# Altium schematic sheet Sheet2.SchDoc(Sheet2)
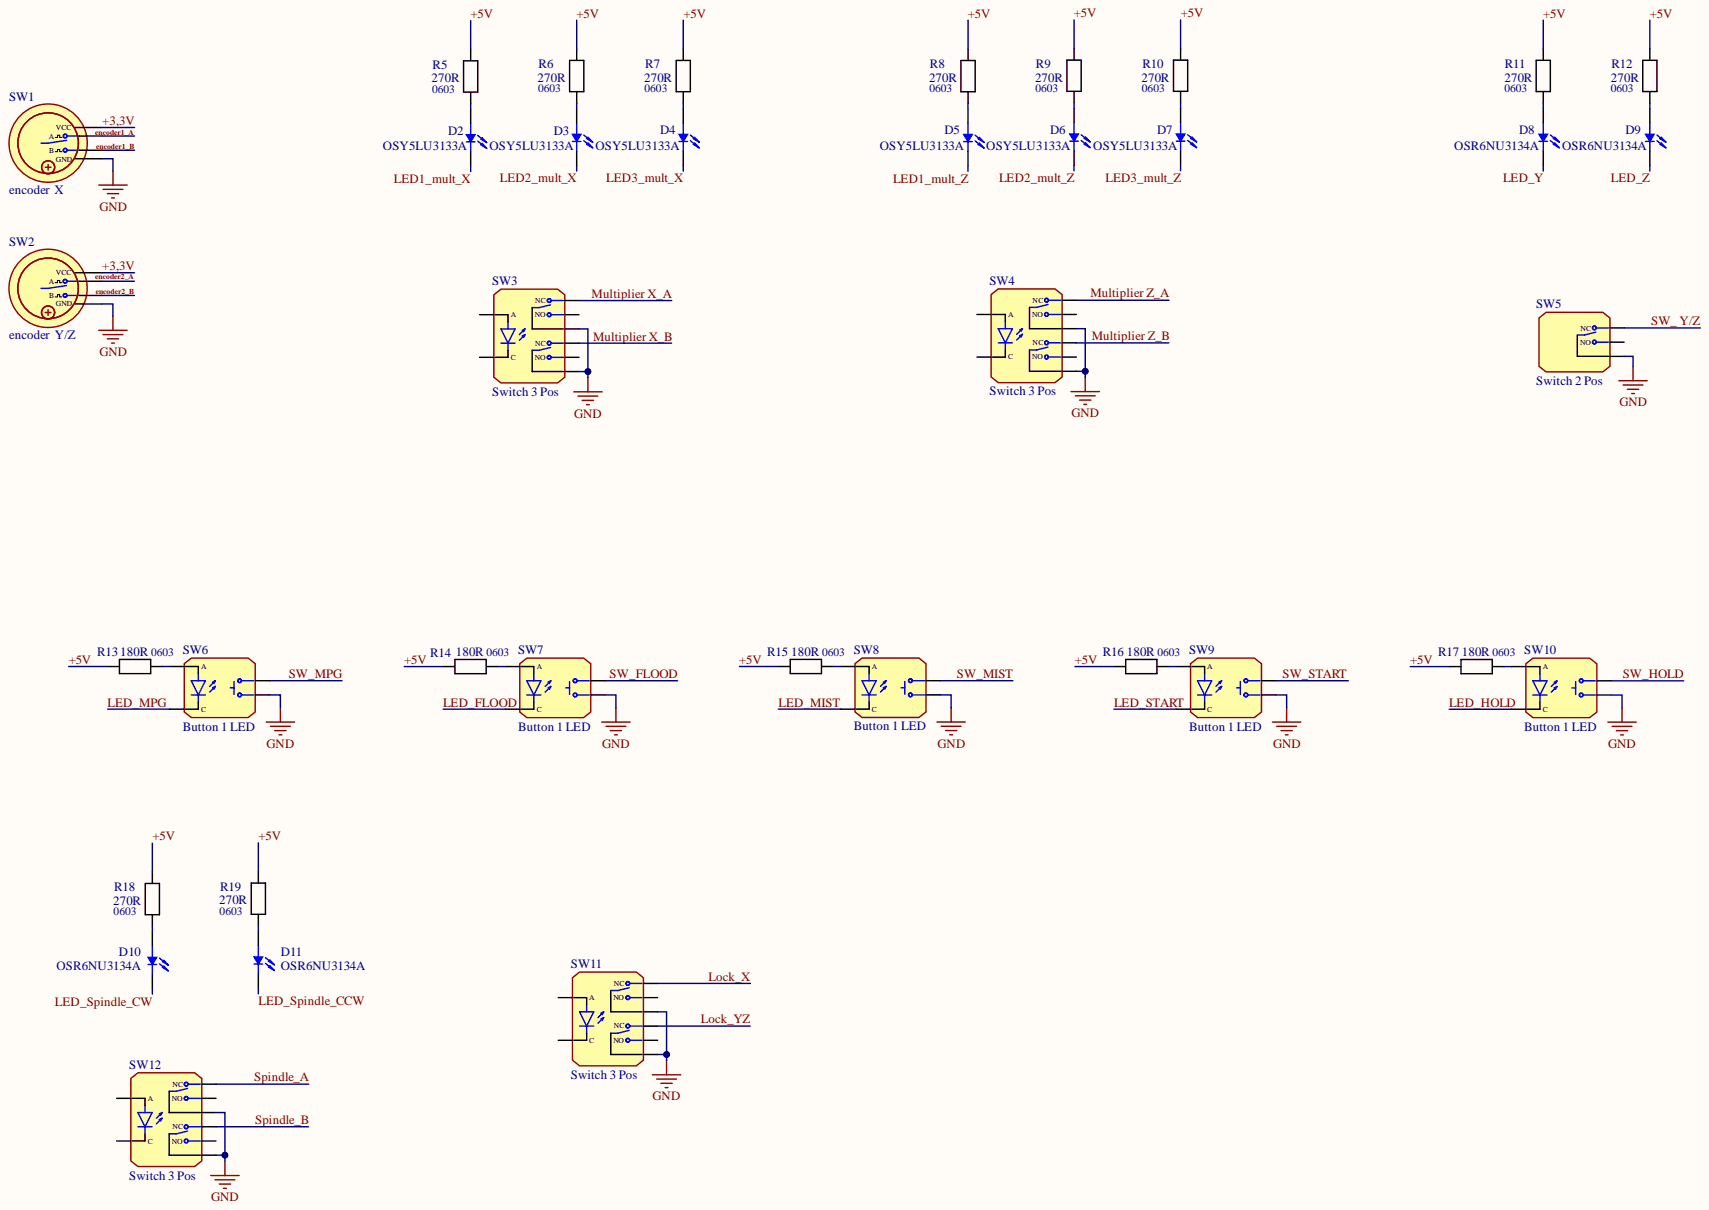
[diagram p0: Sheet2.SchDoc]

## Parts
D2  OSY5LU3133A  [LED-3mm Y]
D3  OSY5LU3133A  [LED-3mm Y]
D4  OSY5LU3133A  [LED-3mm Y]
D5  OSY5LU3133A  [LED-3mm Y]
D6  OSY5LU3133A  [LED-3mm Y]
D7  OSY5LU3133A  [LED-3mm Y]
D8  OSR6NU3134A  [LED-3mm R]
D9  OSR6NU3134A  [LED-3mm R]
D10  OSR6NU3134A  [LED-3mm R]
D11  OSR6NU3134A  [LED-3mm R]
R5  270R  Case: 0603  [SMD 0603]
R6  270R  Case: 0603  [SMD 0603]
R7  270R  Case: 0603  [SMD 0603]
R8  270R  Case: 0603  [SMD 0603]
R9  270R  Case: 0603  [SMD 0603]
R10  270R  Case: 0603  [SMD 0603]
R11  270R  Case: 0603  [SMD 0603]
R12  270R  Case: 0603  [SMD 0603]
R13  180R  Case: 0603  [SMD 0603]
R14  180R  Case: 0603  [SMD 0603]
R15  180R  Case: 0603  [SMD 0603]
R16  180R  Case: 0603  [SMD 0603]
R17  180R  Case: 0603  [SMD 0603]
R18  270R  Case: 0603  [SMD 0603]
R19  270R  Case: 0603  [SMD 0603]
SW1  encoder  Text: X  [PN1X4]
SW2  encoder  Text: Y/Z  [PN1X4]
SW3  Switch 3 Pos  [PN1X8]
SW4  Switch 3 Pos  [PN1X8]
SW5  Switch 2 Pos  [PN1X4]
SW6  Button 1 LED  [PN1X4]
SW7  Button 1 LED  [PN1X4]
SW8  Button 1 LED  [PN1X4]
SW9  Button 1 LED  [PN1X4]
SW10  Button 1 LED  [PN1X4]
SW11  Switch 3 Pos  [PN1X8]
SW12  Switch 3 Pos  [PN1X8]

## Nets
GND: SW1.2(GND) SW2.2(GND) SW3.3 SW3.6 SW4.3 SW4.6 SW5.3 SW6.2 SW7.2 SW8.2 SW9.2 SW10.2 SW11.3 SW11.6 SW12.3 SW12.6
+3,3V: SW1.1(VCC) SW2.1(VCC)
+5V: R5.1 R6.1 R7.1 R8.1 R9.1 R10.1 R11.1 R12.1 R13.2 R14.2 R15.2 R16.2 R17.2 R18.1 R19.1
encoder1_A: SW1.4
encoder1_B: SW1.3
encoder2_A: SW2.4
encoder2_B: SW2.3
LED1_mult_X: D2.C
LED1_mult_Z: D5.C
LED2_mult_X: D3.C
LED2_mult_Z: D6.C
LED3_mult_X: D4.C
LED3_mult_Z: D7.C
LED_FLOOD: SW7.4
LED_HOLD: SW10.4(C)
LED_MIST: SW8.4
LED_MPG: SW6.4
LED_Spindle_CCW: D11.C
LED_Spindle_CW: D10.C
LED_START: SW9.4
LED_Y: D8.C
LED_Z: D9.C
Lock_X: SW11.1
Lock_YZ: SW11.4
Multiplier X_A: SW3.1
Multiplier X_B: SW3.4
Multiplier Z_A: SW4.1
Multiplier Z_B: SW4.4
Spindle_A: SW12.1
Spindle_B: SW12.4
SW_ Y/Z: SW5.1
SW_FLOOD: SW7.1
SW_HOLD: SW10.1
SW_MIST: SW8.1
SW_MPG: SW6.1
SW_START: SW9.1
NetD2_A: D2.A R5.2
NetD3_A: D3.A R6.2
NetD4_A: D4.A R7.2
NetD5_A: D5.A R8.2
NetD6_A: D6.A R9.2
NetD7_A: D7.A R10.2
NetD8_A: D8.A R11.2
NetD9_A: D9.A R12.2
NetD10_A: D10.A R18.2
NetD11_A: D11.A R19.2
NetR13_1: R13.1 SW6.3
NetR14_1: R14.1 SW7.3
NetR15_1: R15.1 SW8.3
NetR16_1: R16.1 SW9.3
NetR17_1: R17.1 SW10.3(A)

Not connected: SW3 2 5 7 8; SW4 2 5 7(A) 8(C); SW5 2; SW11 2 5 7 8; SW12 2 5 7 8

## Library data of the parts
D2-D7 = KP-2012: LED 3mm, 80mcd@20mA, 590nm Yellow, Transparent, 30°; MF: OPTOSUPPLY INTERNATIONAL; Case: 3 mm; Component Kind: Standard; Library Name: CAN_Library.IntLib; Value: OSY5LU3133A
D8-D11 = KP-2012: 3mm, 20mcd@30mA, 640nm Hi-Eff Red, Diffused, 30°; MF: OPTOSUPPLY INTERNATIONAL; Component Kind: Standard; Library Name: CAN_Library.IntLib; Package: TH 3mm; Value: OSR6NU3134A
R5-R19 = Resistor_2: RES; Component Kind: Standard; HelpURL: https://store.comet.bg/Catalogue/RES/Resistors/#eyJpcHAiOiIxMDAiLCJtIjoiIn0%3D; Library Name: CAN_Library.IntLib
  R5-R12 R18 R19: Value: 270R
  R13-R17: Value: 180R
SW1 SW2 = encoder CNC: Handwheel CNC Pulser, Rotary Encoder, 60mm, 100PPR, 5V, 4Pin, <=120mAq Silver; MF: China; Case: PN1X4; Component Kind: Standard; Library Name: CAN_Library.IntLib; Value: encoder
SW3 SW4 SW11 SW12 = Switch 3 Pos 1 Led: Latching, 22mm,  5V, 8Pin, Silver, Blue led; MF: China; Case: PN1X8; Component Kind: Standard; Library Name: CAN_Library.IntLib; Value: Switch 3 Pos
SW5 = Switch 2 Pos: Latching, 16mm,  5V, 3Pin, Silver; MF: China; Case: PN1X2; Component Kind: Standard; Library Name: CAN_Library.IntLib; Value: Switch 2 Pos
SW6-SW10 = Switch Mom 1 Led: Momentary, 22mm,  5V, 4Pin, Silver, Led; MF: China; Case: PN1X4; Component Kind: Standard; Library Name: CAN_Library.IntLib; Value: Button 1 LED
